# Altium schematic sheet GammaMRICAB_2 - ADconversion.SchDoc
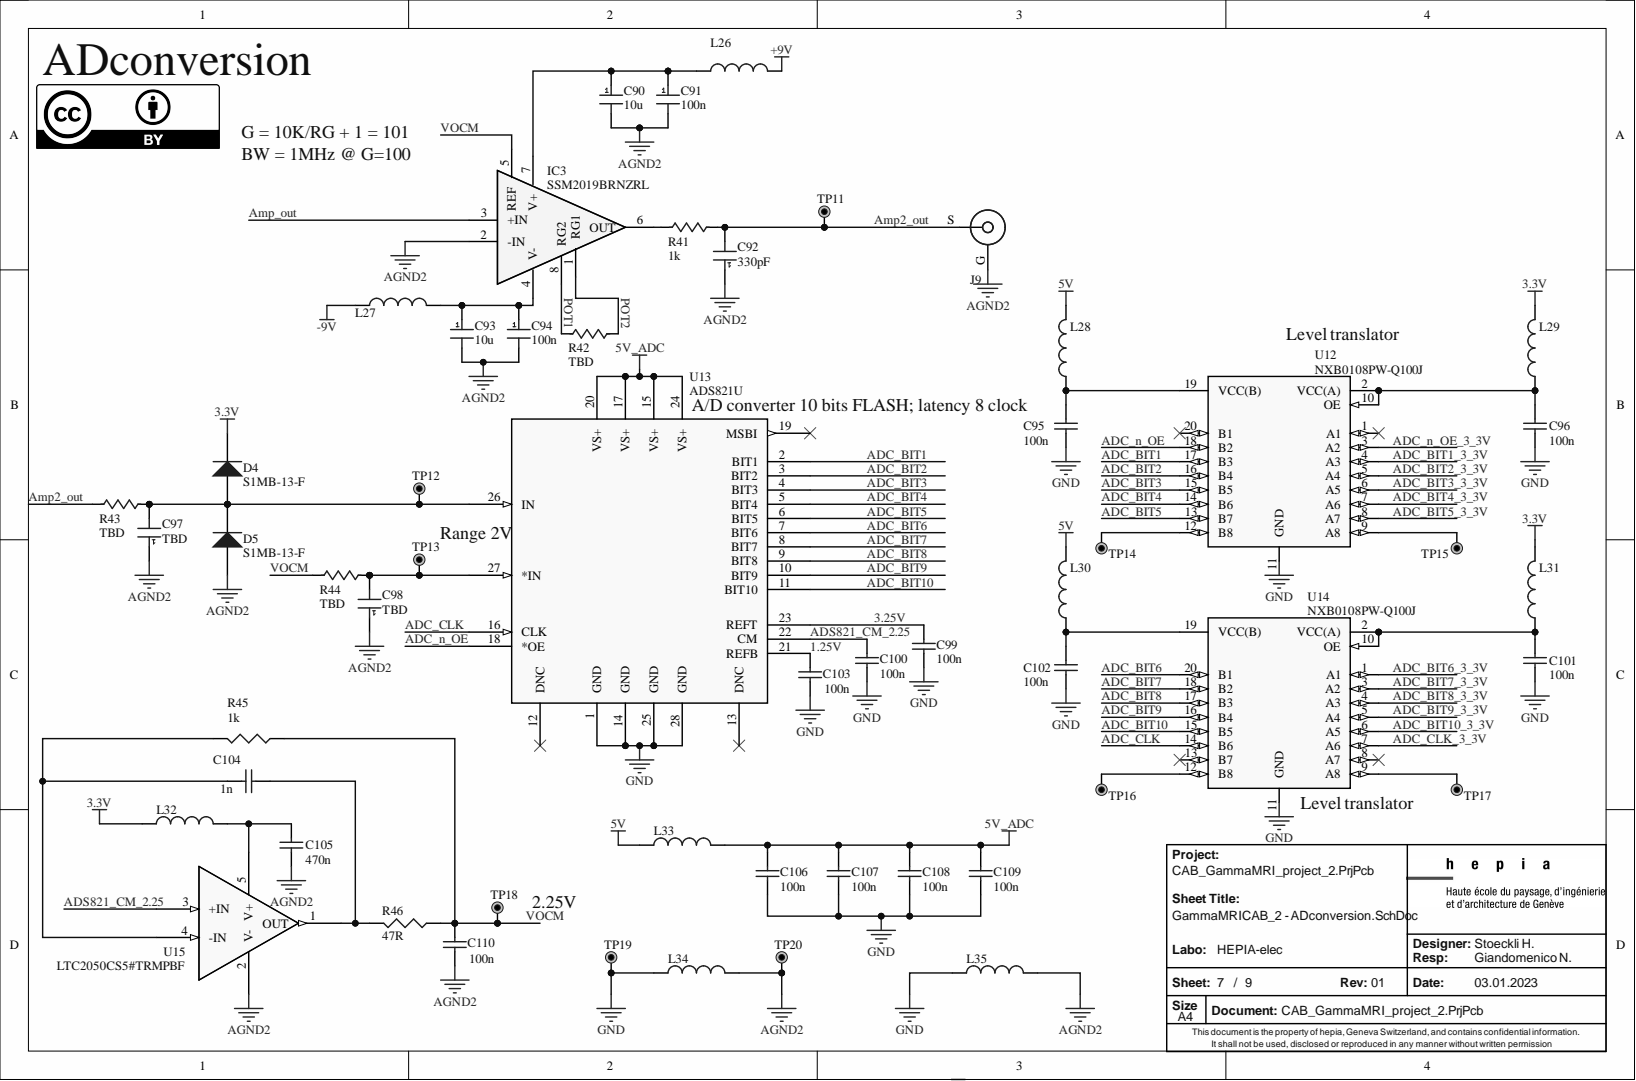
[diagram p0: GammaMRICAB_2 - ADconversion.SchDoc]

## Parts
C90  10u  [6-0805_M]
C91  100n  [1608[0603]]
C92  330pF  [1608[0603]]
C93  10u  [6-0805_M]
C94  100n  [1608[0603]]
C95  100n  [0402]
C96  100n  [0402]
C97  TBD  [1608[0603]]
C98  TBD  [1608[0603]]
C99  100n  [0402]
C100  100n  [0402]
C101  100n  [0402]
C102  100n  [0402]
C103  100n  [0402]
C104  1n  [0402]
C105  470n  [0402]
C106  100n  [0402]
C107  100n  [0402]
C108  100n  [0402]
C109  100n  [0402]
C110  100n  [1608[0603]]
D4  S1MB-13-F  [DIOD-SMB-2_V]
D5  S1MB-13-F  [DIOD-SMB-2_V]
IC3  SSM2019BRNZRL  [SOIC127P600X175-8N]
J9  5227161-2  [TE_5227161-2]  (value not drawn)
L26  BKP0603HS121-T  [1608[0603]]  (value not drawn)
L27  BKP0603HS121-T  [1608[0603]]  (value not drawn)
L28  BKP0603HS121-T  [1608[0603]]  (value not drawn)
L29  BKP0603HS121-T  [1608[0603]]  (value not drawn)
L30  BKP0603HS121-T  [1608[0603]]  (value not drawn)
L31  BKP0603HS121-T  [1608[0603]]  (value not drawn)
L32  BKP0603HS121-T  [1608[0603]]  (value not drawn)
L33  BKP0603HS121-T  [1608[0603]]  (value not drawn)
L34  BKP0603HS121-T  [1608[0603]]  (value not drawn)
L35  BKP0603HS121-T  [1608[0603]]  (value not drawn)
R41  1k  [1608[0603]]
R42  TBD  [1608[0603]]
R43  TBD  [1608[0603]]
R44  TBD  [1608[0603]]
R45  1k  [0402]
R46  47R  [0402]
TP11  TP_PAD_Hole  [TP_PAD_Hole]  (value not drawn)
TP12  TP_PAD_Hole  [TP_PAD_Hole]  (value not drawn)
TP13  TP_PAD_Hole  [TP_PAD_Hole]  (value not drawn)
TP14  TP_PAD_Hole  [TP_PAD_Hole]  (value not drawn)
TP15  TP_PAD_Hole  [TP_PAD_Hole]  (value not drawn)
TP16  TP_PAD_Hole  [TP_PAD_Hole]  (value not drawn)
TP17  TP_PAD_Hole  [TP_PAD_Hole]  (value not drawn)
TP18  TP_PAD_Hole  [TP_PAD_Hole]  (value not drawn)
TP19  TP_PAD_Hole  [TP_PAD_Hole]  (value not drawn)
TP20  TP_PAD_Hole  [TP_PAD_Hole]  (value not drawn)
U12  NXB0108PW-Q100J  [TSSOP20_SOT360-1_NEX-M]
U13  ADS821U  [ADS821]
U14  NXB0108PW-Q100J  [TSSOP20_SOT360-1_NEX-M]
U15  LTC2050CS5#TRMPBF  [S_5_ADI]

## Nets
3.3V: D4.2 L29.2 L31.2 L32.1
5V: L28.2 L30.2 L33.1
5V_ADC: C106.2 C107.2 C108.2 C109.2 L33.2 U13.15(VS+) U13.17(VS+) U13.20(VS+) U13.24(VS+)
+9V: L26.2
-9V: L27.1
AGND2: C90.2 C91.2 C92.1 C93.2 C94.2 C97.1 C98.1 C105.1 C110.1 D5.1 IC3.2(-IN) J9.G L34.2 L35.2 TP20.1 U15.2(V-)
GND: C95.1 C96.1 C99.1 C100.1 C101.1 C102.1 C103.1 C106.1 C107.1 C108.1 C109.1 L34.1 L35.1 TP19.1 U12.11(GND) U13.1(GND) U13.14(GND) U13.25(GND) U13.28(GND) U14.11(GND)
1.25V: C103.2 U13.21(REFB)
3.25V: C99.2 U13.23(REFT)
ADC_BIT1: U12.17(B3) U13.2(BIT1)
ADC_BIT1_3_3V: U12.4(A3)
ADC_BIT2: U12.16(B4) U13.3(BIT2)
ADC_BIT2_3_3V: U12.5(A4)
ADC_BIT3: U12.15(B5) U13.4(BIT3)
ADC_BIT3_3_3V: U12.6(A5)
ADC_BIT4: U12.14(B6) U13.5(BIT4)
ADC_BIT4_3_3V: U12.7(A6)
ADC_BIT5: U12.13(B7) U13.6(BIT5)
ADC_BIT5_3_3V: U12.8(A7)
ADC_BIT6: U13.7(BIT6) U14.20(B1)
ADC_BIT6_3_3V: U14.1(A1)
ADC_BIT7: U13.8(BIT7) U14.18(B2)
ADC_BIT7_3_3V: U14.3(A2)
ADC_BIT8: U13.9(BIT8) U14.17(B3)
ADC_BIT8_3_3V: U14.4(A3)
ADC_BIT9: U13.10(BIT9) U14.16(B4)
ADC_BIT9_3_3V: U14.5(A4)
ADC_BIT10: U13.11(BIT10) U14.15(B5)
ADC_BIT10_3_3V: U14.6(A5)
ADC_CLK: U13.16(CLK) U14.14(B6)
ADC_CLK_3_3V: U14.7(A6)
ADC_n_OE: U12.18(B2) U13.18(*OE)
ADC_n_OE_3_3V: U12.3(A2)
ADS821_CM_2.25: C100.2 U13.22(CM) U15.3(+IN)
Amp2_out: C92.2 J9.S R41.1 R43.2 TP11.1
Amp_out: IC3.3(+IN)
POT1: IC3.8(RG2) R42.2
POT2: IC3.1(RG1) R42.1
VOCM: C110.2 IC3.5(REF) R44.2 R45.2 R46.2 TP18.1
NetC90_1: C90.1 C91.1 IC3.7(V+) L26.1
NetC93_1: C93.1 C94.1 IC3.4(V-) L27.2
NetC95_2: C95.2 L28.1 U12.19(VCC(B))
NetC96_2: C96.2 L29.1 U12.2(VCC(A)) U12.10(OE)
NetC97_2: C97.2 D4.1 D5.2 R43.1 TP12.1 U13.26(IN)
NetC98_2: C98.2 R44.1 TP13.1 U13.27(*IN)
NetC101_2: C101.2 L31.1 U14.2(VCC(A)) U14.10(OE)
NetC102_2: C102.2 L30.1 U14.19(VCC(B))
NetC104_1: C104.1 R45.1 U15.4(-IN)
NetC104_2: C104.2 R46.1 U15.1(OUT)
NetC105_2: C105.2 L32.2 U15.5(V+)
NetIC3_6: IC3.6(OUT) R41.2
NetTP14_1: TP14.1 U12.12(B8)
NetTP15_1: TP15.1 U12.9(A8)
NetTP16_1: TP16.1 U14.12(B8)
NetTP17_1: TP17.1 U14.9(A8)

Not connected: U12 1(A1) 20(B1); U13 12(DNC) 13(DNC) 19(MSBI); U14 8(A7) 13(B7)

## Library data of the parts
C90 C93 = D_CAP-69: Boite Labo 0603: Ceramic Cap, 10uF, 10%, 25V, CAP_0805H1.25; Database Table Name: D_Capacitors$; Component Kind: Standard; Supplier1: Mouser; Library Name: Database_Altium_Hepia_Elec.DbLib
C91 C94 = D_CAP-52: Boite Labo 0603: Ceramic Cap, 100nF, 10%, 50V, CAP_0603H0.8; Database Table Name: D_Capacitors$; Component Kind: Standard; Supplier1: Mouser; Library Name: Database_Altium_Hepia_Elec.DbLib
C92 C97 C98 = D_CAP-52: Boite Labo 0603: Ceramic Cap, 330pF, 10%, 50V, CAP_0603H0.8; Database Table Name: D_Capacitors$; Component Kind: Standard; Supplier1: Mouser; Library Name: Database_Altium_Hepia_Elec.DbLib
C95 C96 C99-C103 C106-C109 = Cap: Capacitor 100nF C0402 X5R 6.3V; Component Kind: Standard; Library Name: Miscellaneous Devices.IntLib; Signal Integrity: Cap; Simulation: CAP; Supplier1: Digikey; Supplier1PN1: 490-6287-6-ND
C104 = Cap: CAP CER 1000PF 50V X7R 0402; Component Kind: Standard; Library Name: Miscellaneous Devices.IntLib; Signal Integrity: Cap; Simulation: CAP; Supplier1: Digikey; Supplier1PN1: 490-4761-1-ND
C105 = Cap: Capacitor 470nF C0402 X5R 6.3V; Component Kind: Standard; Library Name: Miscellaneous Devices.IntLib; Signal Integrity: Cap; Simulation: CAP; Supplier1: Digikey; Supplier1PN1: 311-1684-6-ND
C110 = Cap: CAP CER 0.1UF 50V Y5V 0603; Component Kind: Standard; Library Name: Miscellaneous Devices.IntLib; Signal Integrity: Cap; Simulation: CAP; Supplier1: Digikey; Supplier1PN1: 311-1343-1-ND
D4 D5 = CMP-2000-07013-1: Surface Mount Glass Passivated Rectifier  , 1 A, 1.1 V, -65 to 150 degC, 2-Pin SMD, RoHS, Tape and Reel; Component Kind: Standard; Library Name: Altium Content Vault; Supplier1: Digikey; Supplier1PN1: S1MB-FDICT-ND
IC3 = SSM2019BRNZRL: Integrated Circuit; Component Kind: Standard; Supplier1: Digikey; Supplier1PN1: 505-SSM2019BRNZRLCT-ND; Library Name: CAB_SCH_LIB.SchLib
J9 = 5227161-2: Jack, PCB; 50 Ohms; Right Angle; BNC; Gold (30); Panel; Jack; Valox; Phosphor Bronze; Component Kind: Standard; Supplier1: Digikey; Supplier1PN1: A32245-ND; Library Name: CAB_SCH_LIB.SchLib
L26-L35 = Inductor: FERRITE BEAD 120 OHM 0603(1608) 1LN; Component Kind: Standard; Library Name: Miscellaneous Devices.IntLib; Signal Integrity: Inductor; Simulation: INDUCTOR; Supplier1: Digikey; Supplier1PN1: 553-2387-1-ND
R41-R44 = D_RES-120: Thick Film Resistor, Boite Labo 0603, 1k 1%; Database Table Name: D_Resistors$; Component Kind: Standard; Supplier1: Digikey; Library Name: Database_Altium_Hepia_Elec.DbLib
R45 = Res3: Resistor 1kOhm 1% 0402; Component Kind: Standard; Library Name: Miscellaneous Devices.IntLib; Signal Integrity: Res; Simulation: RESISTOR; Supplier1: Digikey; Supplier1PN1: 311-1.00KLRCT-ND
R46 = Res3: Resistor 47Ohm 1% 0402; Component Kind: Standard; Library Name: Miscellaneous Devices.IntLib; Signal Integrity: Res; Simulation: RESISTOR; Supplier1: Digikey; Supplier1PN1: P47JCT-ND
U12 U14 = NXB0108PW-Q100J: No Description Available; Component Kind: Standard; Supplier1: Digikey; Supplier1PN1: 1727-NXB0108PW-Q100JCT-ND; Library Name: CAB_SCH_LIB.SchLib
U13 = ADS821U: No Description Available; Component Kind: Standard; Supplier1: Digikey; Supplier1PN1: ADS821U-ND; Library Name: CAB_SCH_LIB.SchLib
U15 = LTC2050CS5#TRMPBF: No Description Available; Component Kind: Standard; Supplier1: Digikey; Supplier1PN1: LTC2050CS5#TRMPBFCT-ND; Library Name: CAB_SCH_LIB.SchLib
TP11-TP20 = TP_PAD_Hole: Component Kind: Standard; Library Name: CAB_SCH_LIB.SchLib
